# Altium schematic sheet USB.SchDoc(USB)
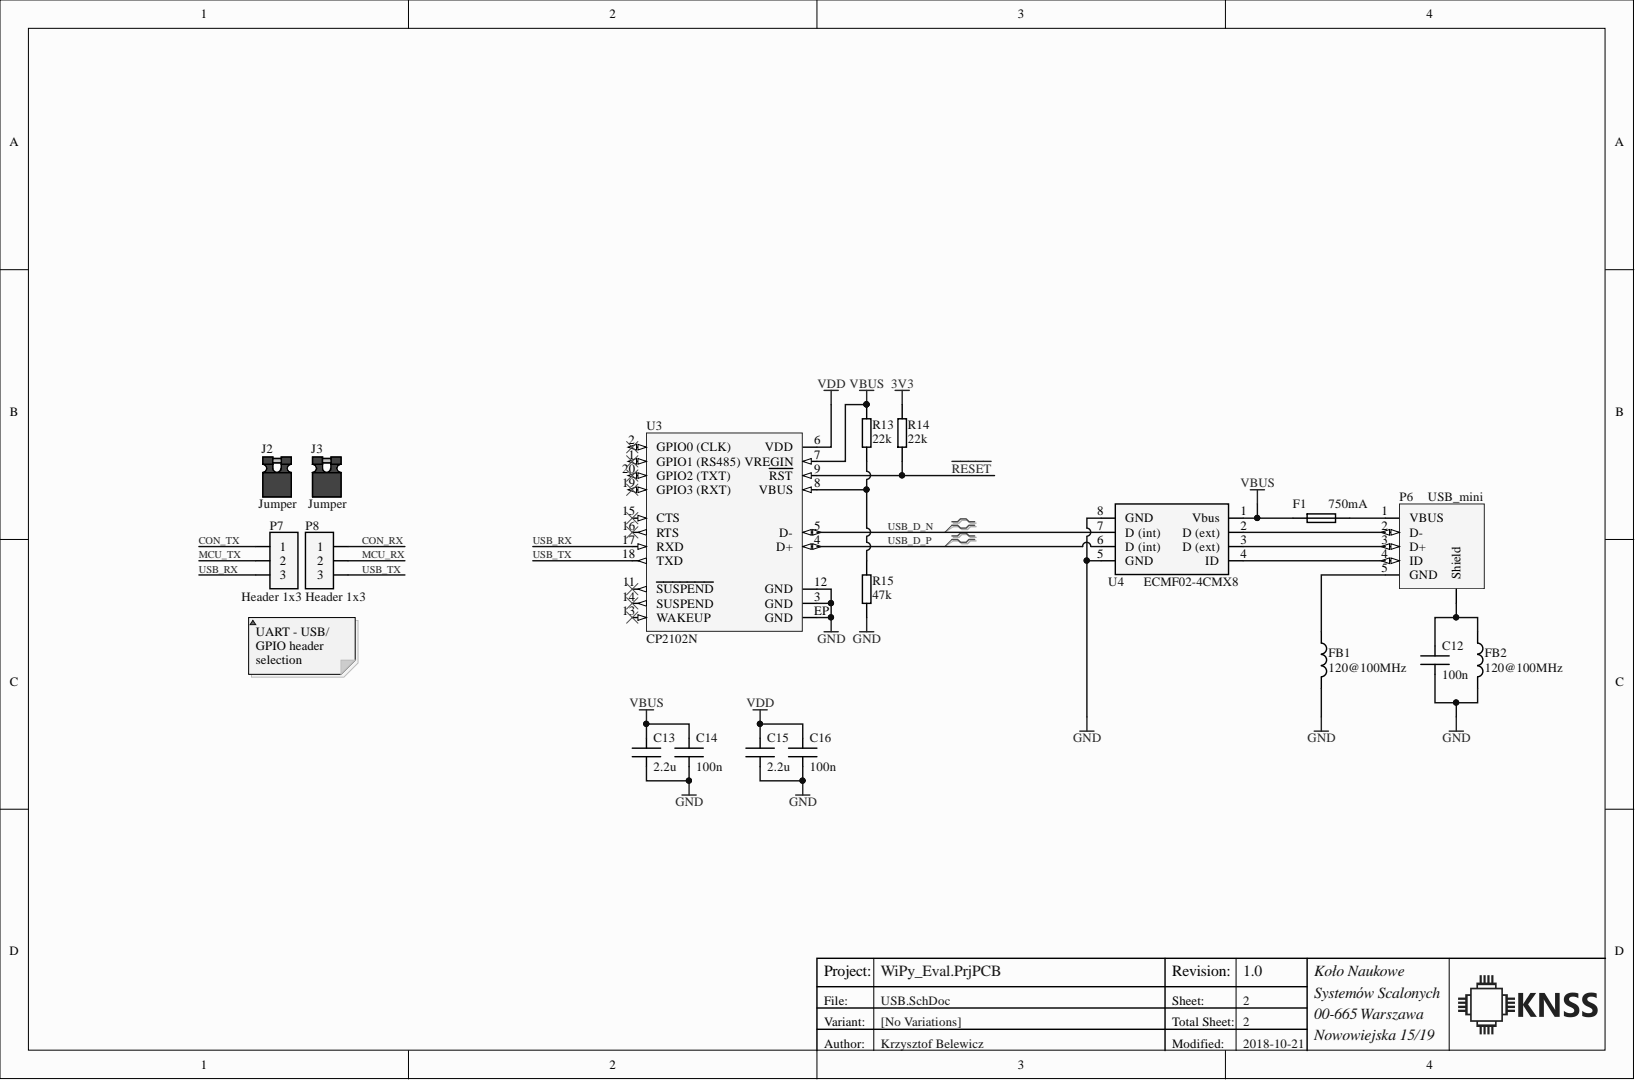
[diagram p0: USB.SchDoc]

## Parts
C12  100n  [CAPC1005N]
C13  value 2.2u  [CAPC1005N]  (hidden comment: Capacitor)
C14  100n  [CAPC1005N]
C15  value 2.2u  [CAPC1005N]  (hidden comment: Capacitor)
C16  100n  [CAPC1005N]
F1  Stand Current: 750mA  [RESC2012N]  (hidden comment: PTC Fuse)
FB1  120@100MHz  [INDC1005N]
FB2  120@100MHz  [INDC1005N]
J2  Jumper  [JUMPER-B]
J3  Jumper  [JUMPER-B]
P6  USB_mini  [MUSB-B5-S-RA-TSMT-CS1]
P7  Header 1x3  [Header 1x3, Male, 2.54mm, Straight, THT]
P8  Header 1x3  [Header 1x3, Male, 2.54mm, Straight, THT]
R13  value 22k  [RESC1005N]  (hidden comment: Resistor)
R14  value 22k  [RESC1005N]  (hidden comment: Resistor)
R15  value 47k  [RESC1005N]  (hidden comment: Resistor)
U3  CP2102N  [QFN-20]
U4  ECMF02-4CMX8  [uQFN-8]

## Nets
3V3: R14.2
GND: C12.1 C13.1 C14.1 C15.1 C16.1 FB1.2 FB2.2 R15.1 U3.3(GND) U3.12(GND) U3.EP(GND) U4.5(GND) U4.8(GND)
VBUS: C13.2 C14.2 F1.1 R13.2 U3.7 U4.1(Vbus)
VDD: C15.2 C16.2 U3.6(VDD)
CON_RX: P8.1
CON_TX: P7.1
MCU_RX: P8.2
MCU_TX: P7.2
~{RESET}: R14.1 U3.9(RST)
USB_D_N: U3.5(D-) U4.7(D_(int))
USB_D_P: U3.4(D+) U4.6(D_(int))
USB_RX: P7.3 U3.17(RXD)
USB_TX: P8.3 U3.18(TXD)
NetC12_2: C12.2 FB2.1 P6.0(Shield)
NetF1_2: F1.2 P6.1(VBUS)
NetFB1_1: FB1.1 P6.5(GND)
NetP6_2: P6.2(D-) U4.2(D_(ext))
NetP6_3: P6.3(D+) U4.3(D_(ext))
NetP6_4: P6.4(ID) U4.4(ID)
NetR13_1: R13.1 R15.2 U3.8(VBUS)

Not connected: U3 1(GPIO1_(RS485)) 2(GPIO0_(CLK)) 11(SUSPEND) 13(WAKEUP) 14(SUSPEND) 15(CTS) 16(RTS) 19(GPIO3_(RXT)) 20(GPIO2_(TXT))

## Library data of the parts
C12 C14 C16 = Capacitor: 0402 SMD Capacitor; Manufacturer Part Number 1: CL05B104KO5NNNC; Manufacturer 1: Samsung; Tolerance: 10%; MaxVoltage: 16V; Value: 100n; Supplier Part Number 2: 2627343; Supplier Part Number 1: CL05B104KO5NNNC; Supplier 2: Farnell; Supplier 1: TME; Manufacturer Part Number 2: MC0402B104K100CT; Manufacturer 2: Multicomp; Library Name: RLC.IntLib; Component Kind: Standard
C13 C15 = Capacitor: 0402 SMD Capacitor; Manufacturer Part Number 1: CL05A225KQ5NSNC; Manufacturer 1: Samsung; Tolerance: 10%; MaxVoltage: 6.3V; Supplier Part Number 2: 2672104; Supplier Part Number 1: CL05A225KQ5NSNC; Supplier 2: Farnell; Supplier 1: TME; Manufacturer Part Number 2: GRT155C80J225KE01D; Manufacturer 2: MURATA; Library Name: RLC.IntLib; Component Kind: Standard
F1 = SD075-16: PTC Fuse 500mA; Manufacturer Part Number 1: SR075-06; Manufacturer 1: ECE; Max Voltage: 6V; Supplier Part Number 1: SR075-06; Supplier 1: TME; Library Name: PTC_Fuse.IntLib; Component Kind: Standard
FB1 FB2 = Inductor: Ferrite Bead; Manufacturer Part Number 1: BLM15PD121SN1D; Manufacturer 1: Murata; Tolerance: 25%; Max Current: 1.3A; Value: 120@100MHz; Supplier Part Number 2: 2443229; Supplier Part Number 1: BLM15PD121SN1D; Supplier 2: Farnell; Supplier 1: TME; Manufacturer Part Number 2: BLM15PD121SN1D; Manufacturer 2: Murata; Library Name: RLC.IntLib; Component Kind: Standard
J2 J3 = Header Jumper Black: 1x2, 2.54mm Black header jumper; Manufacturer 1: NINIGI; Supplier Part Number 1: JUMPER-B; Supplier 1: TME; Socket: No; SMD: No; Pin count: 0; Manufacturer Part Number 1: JUMPER-B; Library Name: Ninigi_Jumpers.IntLib; Component Kind: Standard
P6 = MUSB-B5-S-RA-TSMT-CS1: Gniazdo; USB B mini; SMT; poziome; V: USB 2.0; złocony; Manufacturer 1: ADAM TECH; Supplier Part Number 1: MUSB-B5-S-RA-SMT; Supplier 1: TME; Socket: No; SMD: Yes; Pin count: 5; Manufacturer Part Number 1: MUSB-B5-S-RA-TSMT-CS1; Library Name: Adam Tech Connectors.IntLib; Component Kind: Standard
P7 P8 = Header 1x3: Pin header, 1x3, 2.54mm; Manufacturer Part Number 1: DS1021-1*3SF1-1; Manufacturer 1: CONNFLY; Supplier Part Number 1: ZL201-03G; Supplier 1: TME; Library Name: Header.IntLib; Component Kind: Standard
R13 R14 = Resistor: 0402 Film SMD Resistor; Manufacturer Part Number 1: CRGCQ0402F22K; Manufacturer 1: TE CONNECTIVITY; Tolerance: 1%; Power: 0.1W; Supplier Part Number 2: CRCW040222K0FKTDBC; Supplier Part Number 1: 2861416; Supplier 2: TME; Supplier 1: Farnell; Manufacturer Part Number 2: CRCW040222K0FKTDBC; Manufacturer 2: VISHAY; Library Name: RLC.IntLib; Component Kind: Standard
R15 = Resistor: 0402 Film SMD Resistor; Manufacturer Part Number 1: CRGCQ0402F47K; Manufacturer 1: TE CONNECTIVITY; Tolerance: 1%; Power: 0.1W; Supplier Part Number 2: SMD0402-47K-1%; Supplier Part Number 1: 2861420; Supplier 2: TME; Supplier 1: Farnell; Manufacturer Part Number 2: 0402WGF4702TCE; Manufacturer 2: ROYAL OHM; Library Name: RLC.IntLib; Component Kind: Standard
U3 = CP2102N: USB Interface IC USBXpress - USB to UART Bridge QFN20; Manufacturer Part Number 1: CP2102N-A01-GQFN20; Manufacturer 1: SILICON LABS; Supplier Part Number 2: 634-CP2102NA01GQFN20; Supplier Part Number 1: 2577277; Supplier 2: Mouser; Supplier 1: Farnell; Socket: No; SMD: Yes; Pin count: 20; Manufacturer Part Number 2: CP2102N-A01-GQFN20; Manufacturer 2: SILICON LABS; Library Name: SiliconLabs.IntLib; Component Kind: Standard
U4 = ECMF02-4CMX8: STMicroelectronics Common Mode Filter with ESD protection, USB compatible; Supplier Part Number 1: 2629721; Supplier 1: Farnell; Manufacturer Part Number 1: ECMF02-4CMX8; Manufacturer 1: STMicroelectronics; Component Kind: Standard; Library Name: STMicroelectronics_Protective.IntLib
